# Altium schematic sheet LEDs.SchDoc(LEDs)
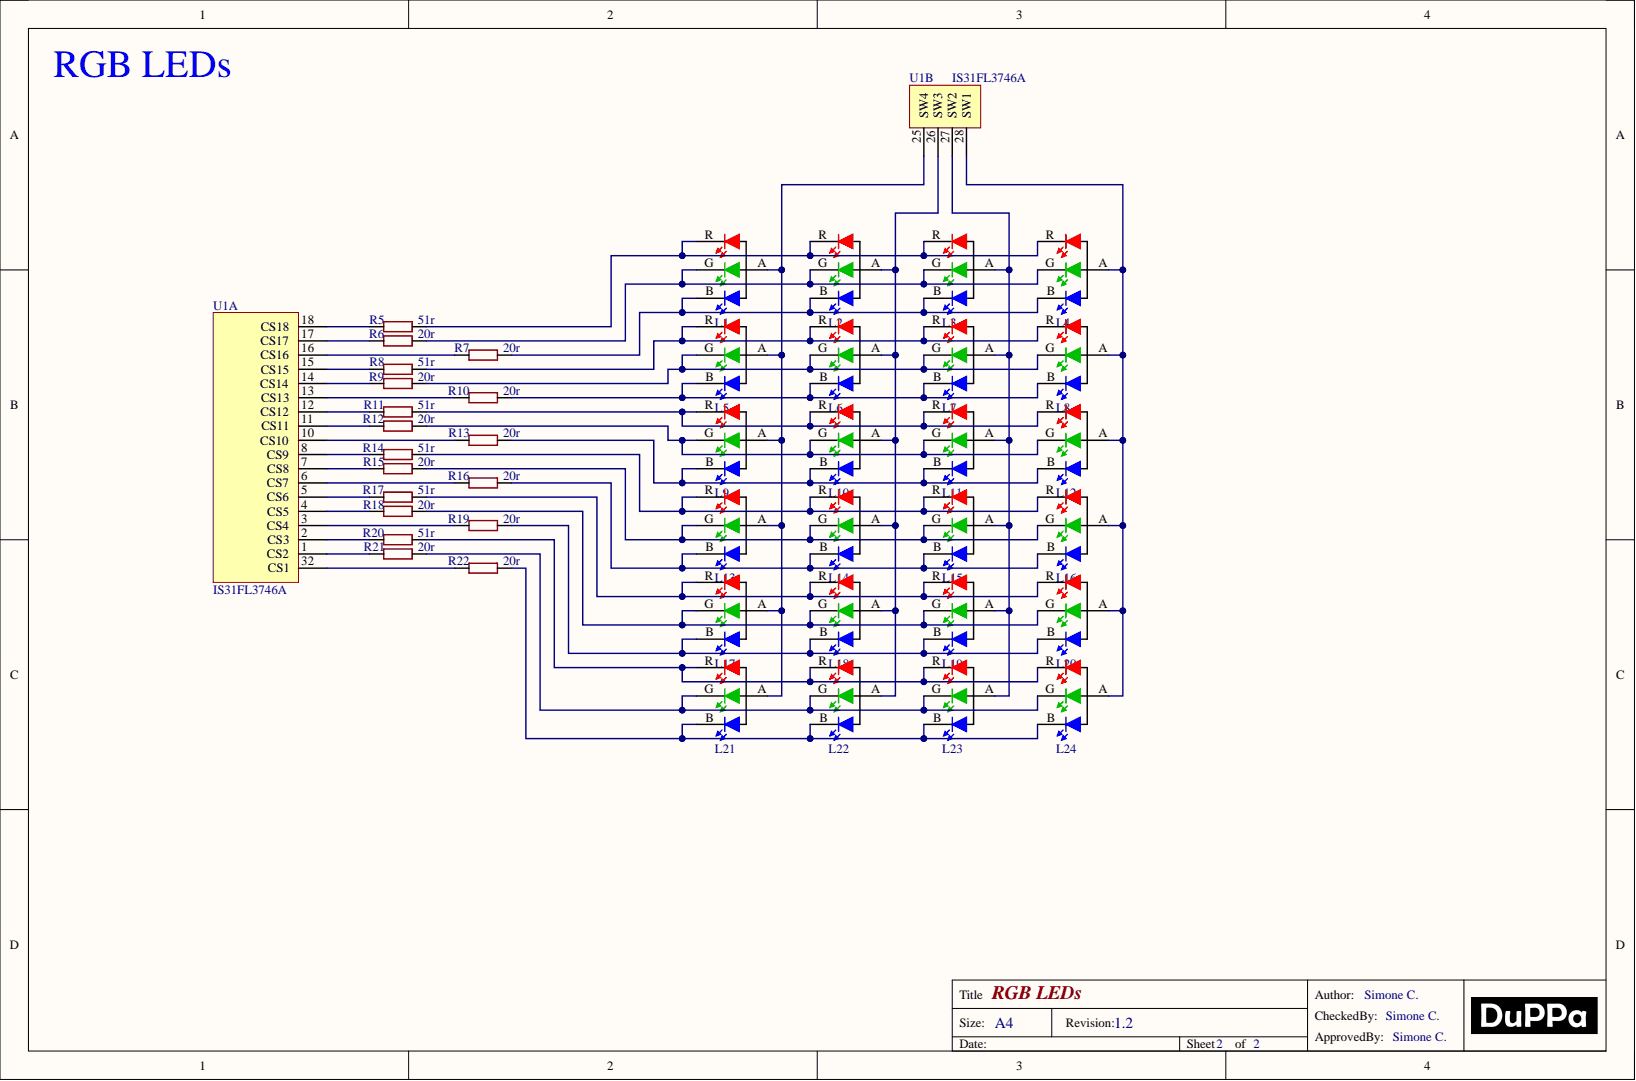
[diagram p0: LEDs.SchDoc]

## Parts
L1  RGB_2020_NH-Z1921RGBA-SG  [RGB_2121_NH-Z1921RGBA-SG]  (value not drawn)
L2  RGB_2020_NH-Z1921RGBA-SG  [RGB_2121_NH-Z1921RGBA-SG]  (value not drawn)
L3  RGB_2020_NH-Z1921RGBA-SG  [RGB_2121_NH-Z1921RGBA-SG]  (value not drawn)
L4  RGB_2020_NH-Z1921RGBA-SG  [RGB_2121_NH-Z1921RGBA-SG]  (value not drawn)
L5  RGB_2020_NH-Z1921RGBA-SG  [RGB_2121_NH-Z1921RGBA-SG]  (value not drawn)
L6  RGB_2020_NH-Z1921RGBA-SG  [RGB_2121_NH-Z1921RGBA-SG]  (value not drawn)
L7  RGB_2020_NH-Z1921RGBA-SG  [RGB_2121_NH-Z1921RGBA-SG]  (value not drawn)
L8  RGB_2020_NH-Z1921RGBA-SG  [RGB_2121_NH-Z1921RGBA-SG]  (value not drawn)
L9  RGB_2020_NH-Z1921RGBA-SG  [RGB_2121_NH-Z1921RGBA-SG]  (value not drawn)
L10  RGB_2020_NH-Z1921RGBA-SG  [RGB_2121_NH-Z1921RGBA-SG]  (value not drawn)
L11  RGB_2020_NH-Z1921RGBA-SG  [RGB_2121_NH-Z1921RGBA-SG]  (value not drawn)
L12  RGB_2020_NH-Z1921RGBA-SG  [RGB_2121_NH-Z1921RGBA-SG]  (value not drawn)
L13  RGB_2020_NH-Z1921RGBA-SG  [RGB_2121_NH-Z1921RGBA-SG]  (value not drawn)
L14  RGB_2020_NH-Z1921RGBA-SG  [RGB_2121_NH-Z1921RGBA-SG]  (value not drawn)
L15  RGB_2020_NH-Z1921RGBA-SG  [RGB_2121_NH-Z1921RGBA-SG]  (value not drawn)
L16  RGB_2020_NH-Z1921RGBA-SG  [RGB_2121_NH-Z1921RGBA-SG]  (value not drawn)
L17  RGB_2020_NH-Z1921RGBA-SG  [RGB_2121_NH-Z1921RGBA-SG]  (value not drawn)
L18  RGB_2020_NH-Z1921RGBA-SG  [RGB_2121_NH-Z1921RGBA-SG]  (value not drawn)
L19  RGB_2020_NH-Z1921RGBA-SG  [RGB_2121_NH-Z1921RGBA-SG]  (value not drawn)
L20  RGB_2020_NH-Z1921RGBA-SG  [RGB_2121_NH-Z1921RGBA-SG]  (value not drawn)
L21  RGB_2020_NH-Z1921RGBA-SG  [RGB_2121_NH-Z1921RGBA-SG]  (value not drawn)
L22  RGB_2020_NH-Z1921RGBA-SG  [RGB_2121_NH-Z1921RGBA-SG]  (value not drawn)
L23  RGB_2020_NH-Z1921RGBA-SG  [RGB_2121_NH-Z1921RGBA-SG]  (value not drawn)
L24  RGB_2020_NH-Z1921RGBA-SG  [RGB_2121_NH-Z1921RGBA-SG]  (value not drawn)
R5  value 51r  [R0402]  (hidden comment: R-EU_R0402)
R6  value 20r  [R0402]  (hidden comment: R-EU_R0402)
R7  value 20r  [R0402]  (hidden comment: R-EU_R0402)
R8  value 51r  [R0402]  (hidden comment: R-EU_R0402)
R9  value 20r  [R0402]  (hidden comment: R-EU_R0402)
R10  value 20r  [R0402]  (hidden comment: R-EU_R0402)
R11  value 51r  [R0402]  (hidden comment: R-EU_R0402)
R12  value 20r  [R0402]  (hidden comment: R-EU_R0402)
R13  value 20r  [R0402]  (hidden comment: R-EU_R0402)
R14  value 51r  [R0402]  (hidden comment: R-EU_R0402)
R15  value 20r  [R0402]  (hidden comment: R-EU_R0402)
R16  value 20r  [R0402]  (hidden comment: R-EU_R0402)
R17  value 51r  [R0402]  (hidden comment: R-EU_R0402)
R18  value 20r  [R0402]  (hidden comment: R-EU_R0402)
R19  value 20r  [R0402]  (hidden comment: R-EU_R0402)
R20  value 51r  [R0402]  (hidden comment: R-EU_R0402)
R21  value 20r  [R0402]  (hidden comment: R-EU_R0402)
R22  value 20r  [R0402]  (hidden comment: R-EU_R0402)
U1  IS31FL3746A  [PQFN40P400X400X75_HS-33N]  (parts A,B)

## Nets
NetL1_A: L1.A L5.A L9.A L13.A L17.A L21.A U1.25(SW4)
NetL1_B: L1.B L2.B L3.B L4.B R7.1
NetL1_G: L1.G L2.G L3.G L4.G R6.1
NetL1_R: L1.R L2.R L3.R L4.R R5.1
NetL2_A: L2.A L6.A L10.A L14.A L18.A L22.A U1.26(SW3)
NetL3_A: L3.A L7.A L11.A L15.A L19.A L23.A U1.27(SW2)
NetL4_A: L4.A L8.A L12.A L16.A L20.A L24.A U1.28(SW1)
NetL5_B: L5.B L6.B L7.B L8.B R10.1
NetL5_G: L5.G L6.G L7.G L8.G R9.1
NetL5_R: L5.R L6.R L7.R L8.R R8.1
NetL9_B: L9.B L10.B L11.B L12.B R13.1
NetL9_G: L9.G L10.G L11.G L12.G R12.1
NetL9_R: L9.R L10.R L11.R L12.R R11.1
NetL13_B: L13.B L14.B L15.B L16.B R16.1
NetL13_G: L13.G L14.G L15.G L16.G R15.1
NetL13_R: L13.R L14.R L15.R L16.R R14.1
NetL17_B: L17.B L18.B L19.B L20.B R19.1
NetL17_G: L17.G L18.G L19.G L20.G R18.1
NetL17_R: L17.R L18.R L19.R L20.R R17.1
NetL21_B: L21.B L22.B L23.B L24.B R22.1
NetL21_G: L21.G L22.G L23.G L24.G R21.1
NetL21_R: L21.R L22.R L23.R L24.R R20.1
NetR5_2: R5.2 U1.18(CS18)
NetR6_2: R6.2 U1.17(CS17)
NetR7_2: R7.2 U1.16(CS16)
NetR8_2: R8.2 U1.15(CS15)
NetR9_2: R9.2 U1.14(CS14)
NetR10_2: R10.2 U1.13(CS13)
NetR11_2: R11.2 U1.12(CS12)
NetR12_2: R12.2 U1.11(CS11)
NetR13_2: R13.2 U1.10(CS10)
NetR14_2: R14.2 U1.8(CS9)
NetR15_2: R15.2 U1.7(CS8)
NetR16_2: R16.2 U1.6(CS7)
NetR17_2: R17.2 U1.5(CS6)
NetR18_2: R18.2 U1.4(CS5)
NetR19_2: R19.2 U1.3(CS4)
NetR20_2: R20.2 U1.2(CS3)
NetR21_2: R21.2 U1.1(CS2)
NetR22_2: R22.2 U1.32(CS1)

## Library data of the parts
R5 R8 R11 R14 R17 R20 = R-EU_R0402: PartNumber: RC0402JR-0751RL; Component Kind: Standard; Library Name: rcl.IntLib
R6 R7 R9 R10 R12 R13 R15 R16 R18 R19 R21 R22 = R-EU_R0402: PartNumber: RC0402JR-0720RL; Component Kind: Standard; Library Name: rcl.IntLib
L1-L24 = RGB_2020_NH-Z1921RGBA-SG: Component Kind: Standard; Library Name: Miscellaneous Devices.IntLib; PartNumber: NH-Z1921RGBA-SG
U1 = IS31FL3746A: Component Kind: Standard; PartNumber: IS31FL3746A; Library Name: ISSI.IntLib
